# Altium schematic sheet Power.SchDoc
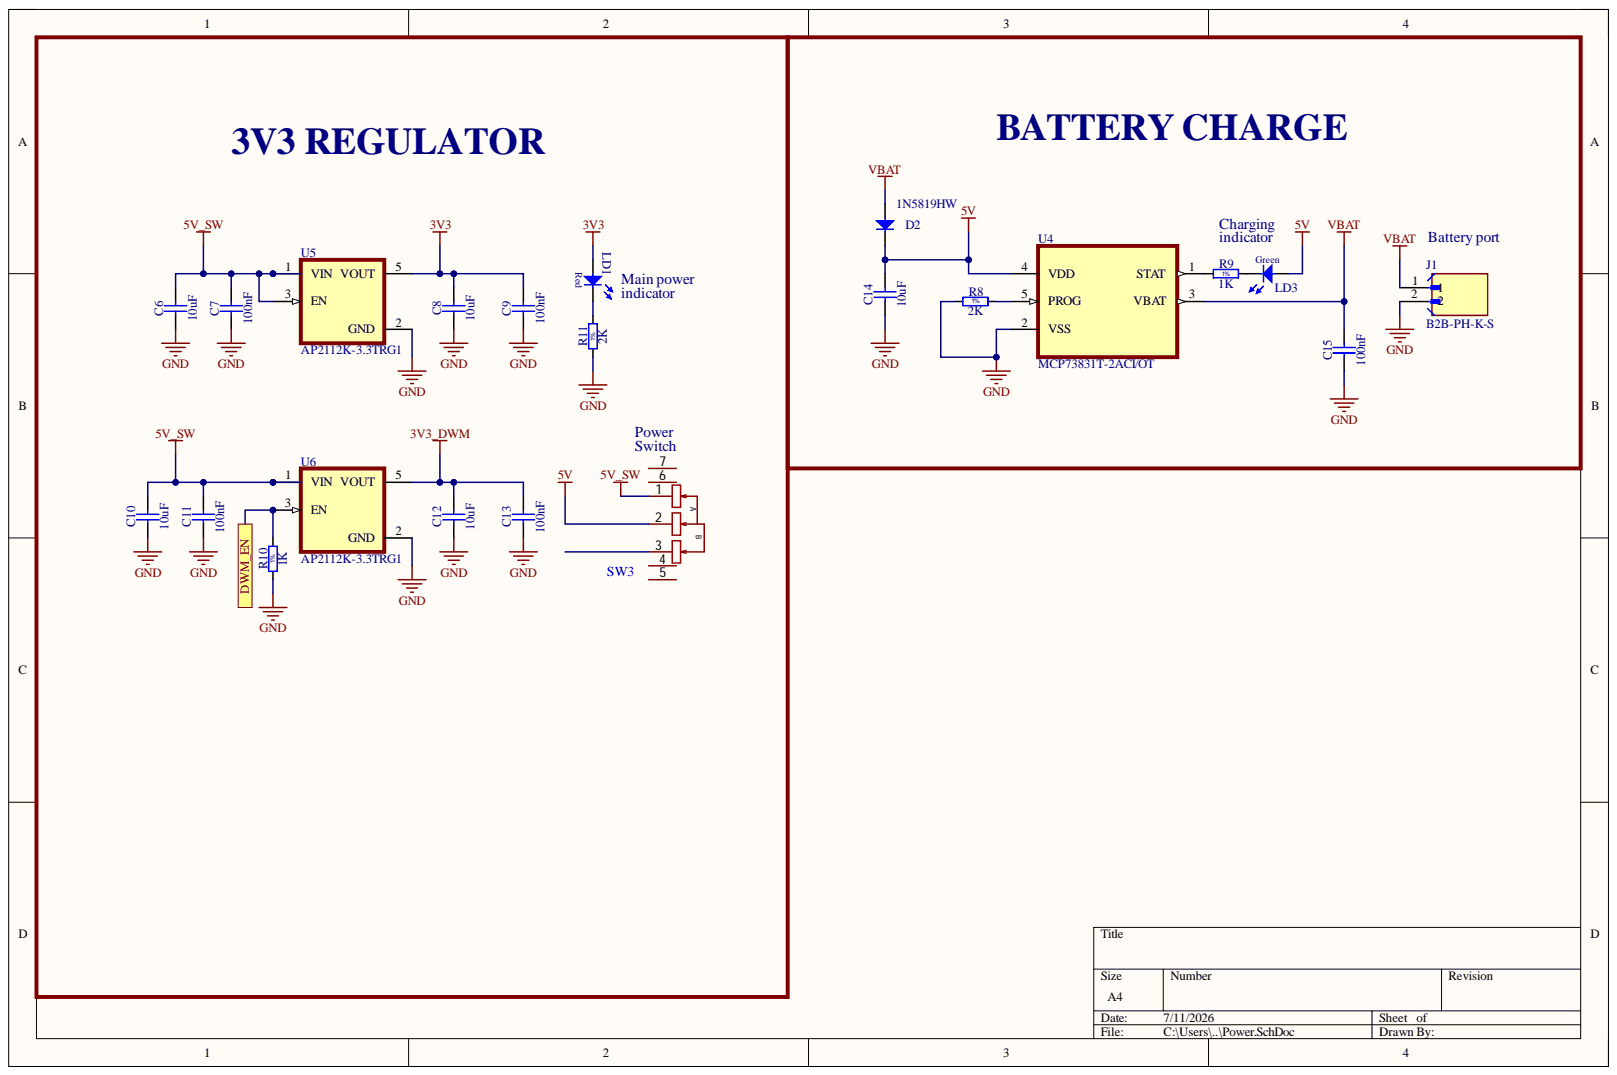
[diagram p0: Power.SchDoc]

## Parts
C6  10uF  [0603C]
C7  100nF  [0603C]
C8  10uF  [0603C]
C9  100nF  [0603C]
C10  10uF  [0603C]
C11  100nF  [0603C]
C12  10uF  [0603C]
C13  100nF  [0603C]
C14  10uF  [0603C]
C15  100nF  [0603C]
D2  1N5819HW  [SOD-123FL]
J1  B2B-PH-K-S  [JST_B2B-PH-K-S]
LD1  (no value)  [0603 LED]
LD3  GREEN  [0603 LED]  (value not drawn)
R8  2K  [0603R]
R9  1K  [0603R]
R10  1K  [0603R]
R11  2K  [0603R]
SW3  MK-12C02-G020  [SW-SMD_MK-12C02-G020]  (value not drawn)
U4  MCP73831T-2ACI/OT  [SOT95P280X145-5N]
U5  AP2112K-3.3TRG1  [SOT95P285X140-5N]
U6  AP2112K-3.3TRG1  [SOT95P285X140-5N]

## Ports
DWM_EN

## Nets
3V3: C8.2 C9.2 LD1.2 U5.5(VOUT)
3V3_DWM: C12.2 C13.2 U6.5(VOUT)
5V: C14.2 D2.1 LD3.2 SW3.2 U4.4(VDD)
5V_SW: C6.2 C7.2 C10.2 C11.2 SW3.1 U5.1(VIN) U5.3(EN) U6.1(VIN)
GND: C6.1 C7.1 C8.1 C9.1 C10.1 C11.1 C12.1 C13.1 C14.1 C15.1 J1.2 R8.1 R10.1 R11.1 U4.2(VSS) U5.2(GND) U6.2(GND)
VBAT: C15.2 D2.2 J1.1 U4.3(VBAT)
DWM_EN: R10.2 U6.3(EN) ; port DWM_EN
NetLD1_1: LD1.1 R11.2
NetLD3_1: LD3.1 R9.2
NetR8_2: R8.2 U4.5(PROG)
NetR9_1: R9.1 U4.1(STAT)

Not connected: SW3 3 4 5 6 7

## Library data of the parts
C6-C15 = Capacitor - non polarized: Non-polarised capacitor; Component Kind: Standard; Library Name: Universal Acq.IntLib
D2 = Diode A1C3: Diode Anode Pin 1 Cathode Pin 3 (Pas de pin 2); Component Kind: Standard; Library Name: Universal Acq.IntLib
J1 = B2B-PH-K-S: Connector Header Through Hole 2 position 0.079 (2.00mm); MF: JST Sales America Inc.; Check_prices: https://www.snapeda.com/parts/B2B-PH-K-S/JST/view-part/?ref=eda; Component Kind: Standard; Library Name: B2B-PH-K-S.IntLib; MP: B2B-PH-K-S; Package: None; SnapEDA_Link: https://www.snapeda.com/parts/B2B-PH-K-S/JST/view-part/?ref=snap
LD1 = LED Red 1C 2A: Component Kind: Standard; Description: LED Red 1C 2A; Library Name: Universal Acq.IntLib
LD3 = LED Green 1C 2A: Component Kind: Standard; Description: LED Green 1C 2A; Library Name: Universal Acq.IntLib
R8-R11 = Resistor - 1%_1: Resistor - 1%; Component Kind: Standard; Library Name: Universal Acq.IntLib
SW3 = MK-12C02-G020: Manufacturer: G-Switch(品赞); Actuator Color: Black; Actuator Style: Rectangular Columnar; Add into BOM: yes; Circuit: SPDT; Current Rating: 1A; Life: 10,000 Cycles; Switch Height: 4.7mm; Voltage Rating: 6V; Component Kind: Standard; Convert to PCB: yes; Datasheet: https://item.szlcsc.com/datasheet/MK-12C02-G020/1046841.html; Device: MK-12C02-G020; JLCPCB Part Class: Extended Part; LCSC Part Name: 微型拨动开关; Length: 6.6mm; Library Name: MK-12C02-G020.IntLib; Manufacturer Part: MK-12C02-G020; Mounting Type: Surface Mount, Right Angle; Operating Temperature: -20℃~+70℃; PCB3D: SW-SMD_MK-12C02-G020; Supplier: LCSC; Supplier Footprint: SMD,6.6x1.4mm; Supplier Part: C963206; Symbol: MK-12C02-G020; Termination Style: -; Width: 1.4mm
U4 = MCP73831T-2ACI/OT: Charger IC Lithium Ion/Polymer SOT-23-5; MF: Microchip; Availability: In Stock; Check_prices: https://www.snapeda.com/parts/MCP73831T-2ACI/OT/Microchip/view-part/?ref=eda; Component Kind: Standard; Library Name: MCP73831T-2ACI_OT.IntLib; MP: MCP73831T-2ACI/OT; Package: SOT-23 Microchip; Price: None; Purchase-URL: https://pricing.snapeda.com/search/part/MCP73831T-2ACI/OT/?ref=eda; SnapEDA_Link: https://www.snapeda.com/parts/MCP73831T-2ACI/OT/Microchip/view-part/?ref=snap
U5 U6 = AP2112K-3.3TRG1: Linear Voltage Regulator IC Positive Fixed 1 Output 600mA SOT-25; MF: Diodes Inc.; Availability: In Stock; Check_prices: https://www.snapeda.com/parts/AP2112K-3.3TRG1/Diodes+Inc./view-part/?ref=eda; Component Kind: Standard; Library Name: AP2112K-3.3TRG1.IntLib; MP: AP2112K-3.3TRG1; Package: SOT-753 Diodes Inc.; Price: None; Purchase-URL: https://pricing.snapeda.com/search/part/AP2112K-3.3TRG1/?ref=eda; SnapEDA_Link: https://www.snapeda.com/parts/AP2112K-3.3TRG1/Diodes+Inc./view-part/?ref=snap
